# Altium schematic sheet 原理图1.SchDoc(原理图1)
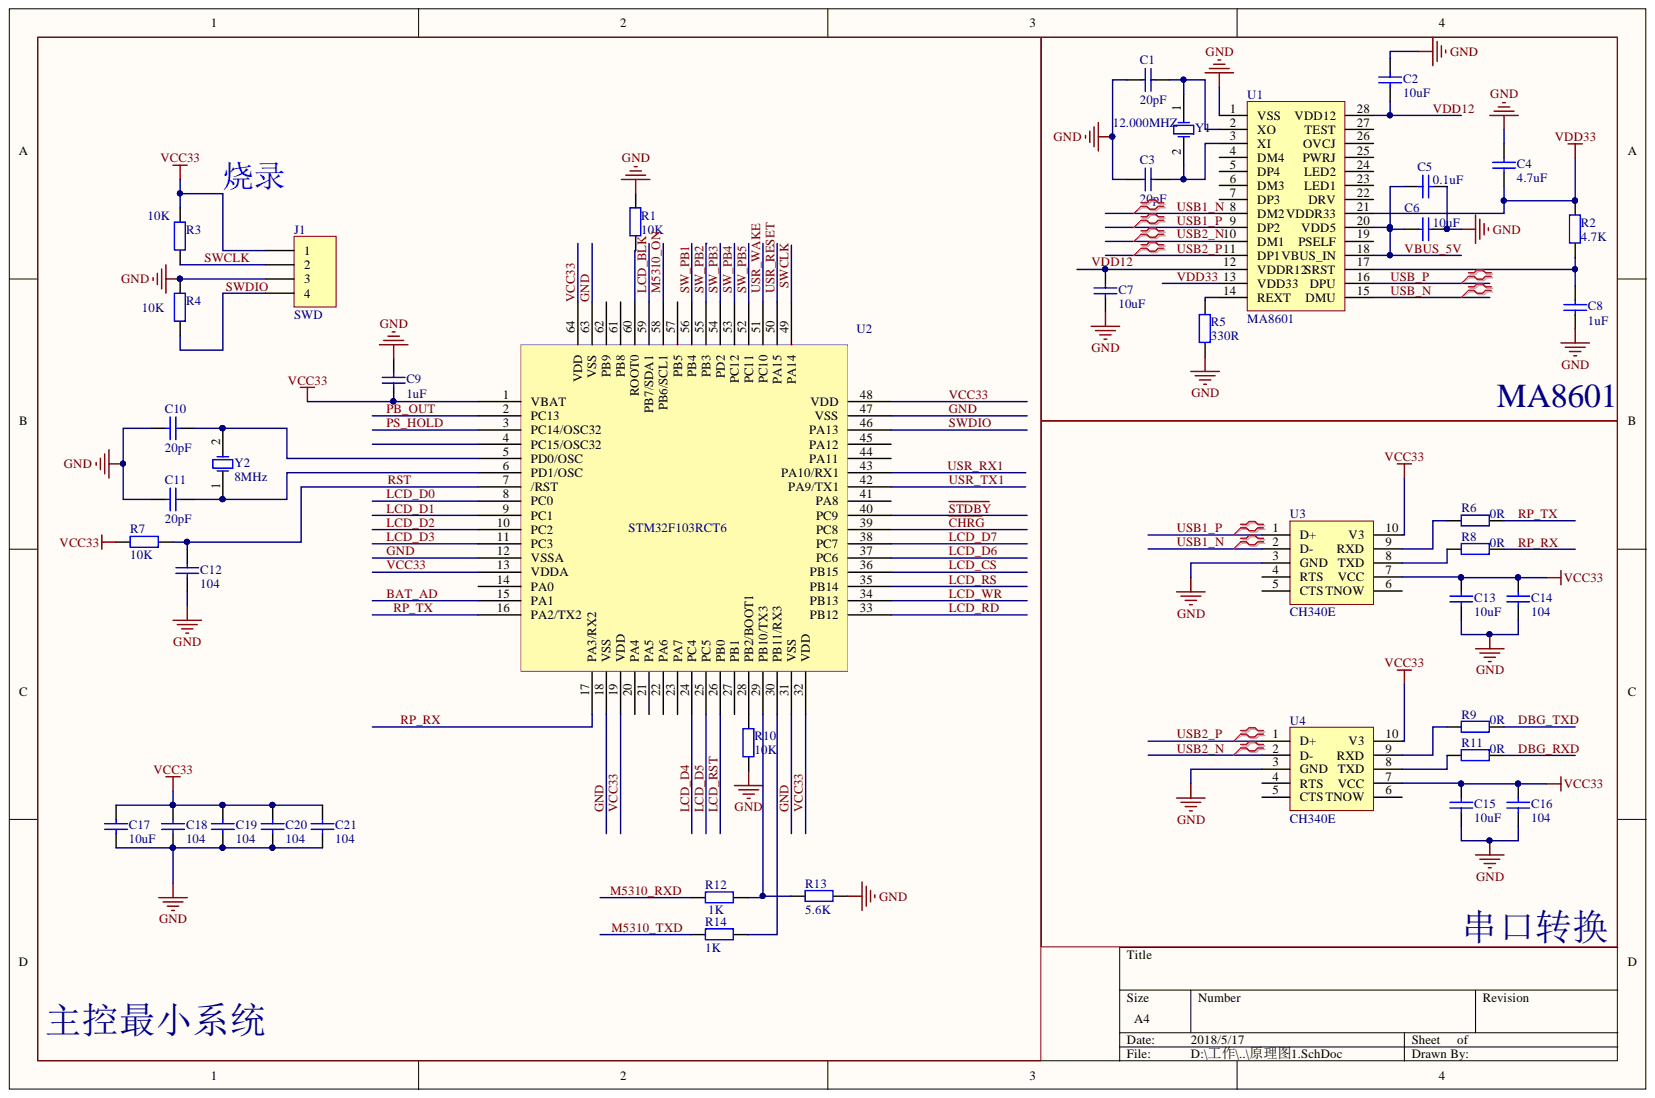
[diagram p0: 原理图1.SchDoc]

## Parts
C1  20pF  [0402C]
C2  10uF  [0402C]
C3  20pF  [0402C]
C4  4.7uF  [0402C]
C5  value 0.1uF  [0402C]  (hidden comment: 100nF)
C6  10uF  [0402C]
C7  10uF  [0402C]
C8  1uF  [0402C]
C9  1uF  [0402C]
C10  20pF  [0402C]
C11  20pF  [0402C]
C12  104  [0402C]
C13  10uF  [0402C]
C14  104  [0402C]
C15  10uF  [0402C]
C16  104  [0402C]
C17  10uF  [0402C]
C18  104  [0402C]
C19  104  [0402C]
C20  104  [0402C]
C21  104  [0402C]
J1  SWD  [HDR1X4]
R1  10K  [0402R]
R2  4.7K  [0402R]
R3  10K  [0402R]
R4  10K  [0402R]
R5  330R  [0402R]
R6  0R  [0402R]
R7  10K  [0402R]
R8  0R  [0402R]
R9  0R  [0402R]
R10  10K  [0402R]
R11  0R  [0402R]
R12  1K  [0402R]
R13  5.6K  [0402R]
R14  1K  [0402R]
U1  MA8601  [SSOP28_MA8601]
U2  STM32F103RCT6  [STM32F103RCT6]
U3  CH340E  [MSOP10]
U4  CH340E  [MSOP10]
Y1  12.000MHZ  [OSC_SMD_5*3.2mm]
Y2  8MHz  [OSC_SMD_5*3.2mm]

## Nets
BAT_AD: U2.15(PA1)
~{CHRG}: U2.39(PC8)
DBG_RXD: R11.1
DBG_TXD: R9.1
GND: C1.1 C2.2 C3.1 C4.2 C5.2 C6.2 C7.1 C8.2 C9.2 C10.1 C11.1 C12.1 C13.2 C14.2 C15.2 C16.2 C17.2 C18.2 C19.2 C20.2 C21.2 J1.3 R1.2 R4.2 R5.2 R10.1 R13.2 U1.1(VSS) U2.12(VSSA) U2.18(VSS) U2.31(VSS) U2.47(VSS) U2.63(VSS) U3.3(GND) U4.3(GND)
LCD_BLK: U2.59(PB7/SDA1)
LCD_CS: U2.36(PB15)
LCD_D0: U2.8(PC0)
LCD_D1: U2.9(PC1)
LCD_D2: U2.10(PC2)
LCD_D3: U2.11(PC3)
LCD_D4: U2.24(PC4)
LCD_D5: U2.25(PC5)
LCD_D6: U2.37(PC6)
LCD_D7: U2.38(PC7)
LCD_RD: U2.33(PB12)
LCD_RS: U2.35(PB14)
LCD_RST: U2.26(PB0)
LCD_WR: U2.34(PB13)
M5310_ON: U2.58(PB6/SCL1)
M5310_RXD: R12.1
M5310_TXD: R14.2
PB_OUT: U2.2(PC13)
PS_HOLD: U2.3(PC14/OSC32)
RP_RX: R8.1 U2.17(PA3/RX2)
RP_TX: R6.1 U2.16(PA2/TX2)
RST: C12.2 R7.1 U2.7(/RST)
~{STDBY}: U2.40(PC9)
SW_PB1: U2.56(PB4)
SW_PB2: U2.55(PB3)
SW_PB3: U2.54(PD2)
SW_PB4: U2.53(PC12)
SW_PB5: U2.52(PC11)
SWCLK: J1.2 R3.1 U2.49(PA14)
SWDIO: J1.4 R4.1 U2.46(PA13)
USB1_N: U1.8(DM2) U3.2(D-)
USB1_P: U1.9(DP2) U3.1(D+)
USB2_N: U1.10(DM1) U4.2(D-)
USB2_P: U1.11(DP1) U4.1(D+)
USB_N: U1.15(DMU)
USB_P: U1.16(DPU)
USR_RESET: U2.50(PA15)
USR_RX1: U2.43(PA10/RX1)
USR_TX1: U2.42(PA9/TX1)
USR_WAKE: U2.51(PC10)
VBUS_5V: C5.1 C6.1 U1.18(VBUS_IN) U1.20(VDD5)
VCC33: C9.1 C13.1 C14.1 C15.1 C16.1 C17.1 C18.1 C19.1 C20.1 C21.1 J1.1 R3.2 R7.2 U2.1(VBAT) U2.13(VDDA) U2.19(VDD) U2.32(VDD) U2.48(VDD) U2.64(VDD) U3.7(VCC) U3.10(V3) U4.7(VCC) U4.10(V3)
VDD12: C2.1 C7.2 U1.12(VDDR12) U1.28(VDD12)
VDD33: C4.1 R2.1 U1.13(VDD33) U1.21(VDDR33)
NetC1_2: C1.2 U1.2(XO) Y1.1
NetC3_2: C3.2 U1.3(XI) Y1.2
NetC8_1: C8.1 R2.2 U1.17(SRST)
NetC10_2: C10.2 U2.5(PD0/OSC) Y2.2
NetC11_2: C11.2 U2.6(PD1/OSC) Y2.1
NetR1_1: R1.1 U2.60(ROOT0)
NetR5_1: R5.1 U1.14(REXT)
NetR6_2: R6.2 U3.9(RXD)
NetR8_2: R8.2 U3.8(TXD)
NetR9_2: R9.2 U4.9(RXD)
NetR10_2: R10.2 U2.28(PB2/BOOT1)
NetR11_2: R11.2 U4.8(TXD)
NetR12_2: R12.2 R13.1 U2.29(PB10/TX3)
NetR14_1: R14.1 U2.30(PB11/RX3)

Not connected: U1 4(DM4) 5(DP4) 6(DM3) 7(DP3) 19(PSELF) 22(DRV) 23(LED1) 24(LED2) 25(PWRJ) 26(OVCJ) 27(TEST); U2 4(PC15/OSC32) 14(PA0) 20(PA4) 21(PA5) 22(PA6) 23(PA7) 27(PB1) 41(PA8) 44(PA11) 45(PA12) 57(PB5) 61(PB8) 62(PB9); U3 4(RTS) 5(CTS) 6(TNOW); U4 4(RTS) 5(CTS) 6(TNOW)

## Library data of the parts
C1-C8 C13-C16 = Cap: Capacitor; Component Kind: Standard; Library Name: Miscellaneous Devices.IntLib
  C1 C3: Value: 20pF
  C2 C6 C7 C13 C15: Value: 10uF
  C4: Value: 4.7uF
  C8: Value: 1uF
  C14 C16: Value: 104
C9-C12 C17-C21 = 0201: Component Kind: Standard; Library Name: Capacitor.IntLib
  C9: Value: 1uF
  C10 C11: Value: 20pF
  C12 C18-C21: Value: 104
  C17: Value: 10uF
J1 = 06030014: 插针、方脚、4PIN、单排、直式、2.54、5.84、2mmPCB、3A、公; Component Kind: Standard; Library Name: Connector.IntLib; Value: SWD
R1 R3 R4 R7 R10 R13 R14 = 0101: Component Kind: Standard; Library Name: Resistor.IntLib
  R1 R10: Value: 10K
  R13: Value: 5.6K
  R14: Value: 1K
R2 R5 R6 R8 R9 R11 = Res2: Resistor; Component Kind: Standard; Library Name: Miscellaneous Devices.IntLib
  R2: Value: 4.7K
  R5: Value: 330R
  R6 R8 R9 R11: Value: 0R
R12 = 0101: Value: 0603; Component Kind: Standard; Library Name: Resistor.IntLib
U2 = STM32F103RBT6: Component Kind: Standard; Description: STM32F103RCT6; Library Name: GPRS.SchLib
Y1 Y2 = XTAL: Crystal Oscillator; Component Kind: Standard; LatestRevisionDate: 17-Jul-2002; LatestRevisionNote: Re-released for DXP Platform.; Library Name: Miscellaneous Devices.IntLib; PackageDescription: Crystal, Thru-Hole; 2 Leads; Body 3.1 x 8.2 mm (Dia.xH); PackageReference: R38; Published: 8-Jun-2000; Publisher: Altium Limited
U1 = MA8601: Component Kind: Standard; Library Name: 参考设计库.SchLib
U3 U4 = CH340E: Component Kind: Standard; Library Name: 参考设计库.SchLib
